# Altium schematic sheet GSM.SchDoc
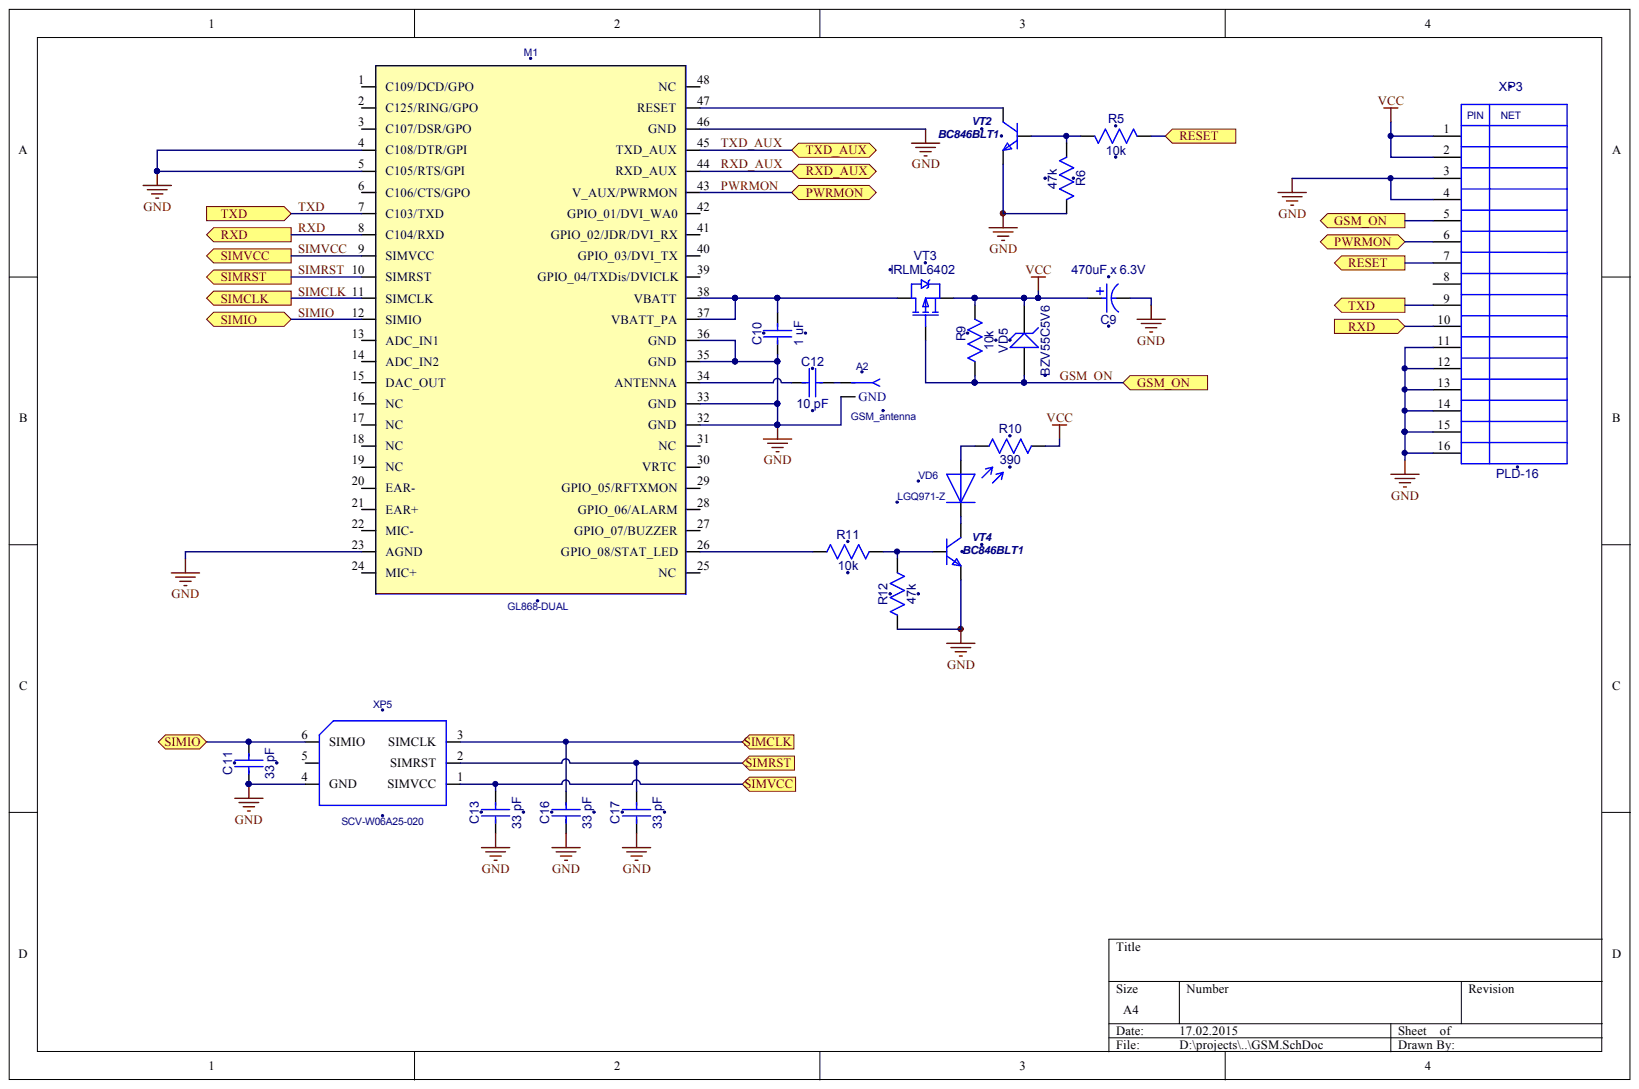
[diagram p0: GSM.SchDoc]

## Parts
A2  GSM_antenna  [GSM_antenna_pad]
C9  470uF x 6.3V  [TAJ-D-1M]
C10  1 uF  [CC0603-3M]
C11  33 pF  [CC0603-3M]
C12  10 pF  [CC0603-3M]
C13  33 pF  [CC0603-3M]
C16  33 pF  [CC0603-3M]
C17  33 pF  [CC0603-3M]
M1  GL868-DUAL  [GL868-DUAL]
R5  10k  [RC0603-3M]
R6  47k  [RC0603-3M]
R9  10k  [RC0603-3M]
R10  390  [RC0603-3M]
R11  10k  [RC0603-3M]
R12  47k  [RC0603-3M]
VD5  BZV55C5V6  [SOD80]
VD6  LGQ971-Z  [LED0603]
VT2  BC846BLT1  [SOT-23(123)]
VT3  IRLML6402  [SOT-23(123)]
VT4  BC846BLT1  [SOT-23(123)]
XP3  PLD-16  [PIN16]
XP5  SCV-W06A25-020  [SCVW06A25020]

## Ports
GSM_ON, PWRMON, RESET, RXD, RXD_AUX, SIMCLK, SIMIO, SIMRST, SIMVCC, TXD, TXD_AUX

## Nets
GND: A2.2 C9.2 C10.1 C11.1 C13.1 C16.1 C17.1 M1.4(C108/DTR/GPI) M1.5(C105/RTS/GPI) M1.23(AGND) M1.32(GND) M1.33(GND) M1.35(GND) M1.36(GND) M1.46(GND) R6.2 R12.2 VT2.2 VT4.2 XP3.3 XP3.4 XP3.11 XP3.12 XP3.13 XP3.14 XP3.15 XP3.16 XP5.4(GND)
VCC: C9.1 R9.1 R10.2 VD5.2 VT3.2 XP3.1 XP3.2
GSM_ON: R9.2 VD5.1 VT3.1 XP3.5 ; port GSM_ON
PWRMON: M1.43(V_AUX/PWRMON) XP3.6 ; port PWRMON
RESET: R5.1 XP3.7 ; port RESET
RXD: M1.8(C104/RXD) XP3.10 ; port RXD
RXD_AUX: M1.44(RXD_AUX) ; port RXD_AUX
SIMCLK: C16.2 M1.11(SIMCLK) XP5.3(SIMCLK) ; port SIMCLK
SIMIO: C11.2 M1.12(SIMIO) XP5.6(SIMIO) ; port SIMIO
SIMRST: C17.2 M1.10(SIMRST) XP5.2(SIMRST) ; port SIMRST
SIMVCC: C13.2 M1.9(SIMVCC) XP5.1(SIMVCC) ; port SIMVCC
TXD: M1.7(C103/TXD) XP3.9 ; port TXD
TXD_AUX: M1.45(TXD_AUX) ; port TXD_AUX
NetA2_1: A2.1 C12.2
NetC10_2: C10.2 M1.37(VBATT_PA) M1.38(VBATT) VT3.3
NetC12_1: C12.1 M1.34(ANTENNA)
NetM1_26: M1.26(GPIO_08/STAT_LED) R11.1
NetM1_47: M1.47(RESET) VT2.3
NetR5_2: R5.2 R6.1 VT2.1
NetR10_1: R10.1 VD6.1
NetR11_2: R11.2 R12.1 VT4.1
NetVD6_2: VD6.2 VT4.3

Not connected: M1 1(C109/DCD/GPO) 2(C125/RING/GPO) 3(C107/DSR/GPO) 6(C106/CTS/GPO) 13(ADC_IN1) 14(ADC_IN2) 15(DAC_OUT) 16(NC) 17(NC) 18(NC) 19(NC) 20(EAR-) 21(EAR+) 22(MIC-) 24(MIC+) 25(NC) 27(GPIO_07/BUZZER) 28(GPIO_06/ALARM) 29(GPIO_05/RFTXMON) 30(VRTC) 31(NC) 39(GPIO_04/TXDis/DVICLK) 40(GPIO_03/DVI_TX) 41(GPIO_02/JDR/DVI_RX) 42(GPIO_01/DVI_WA0) 48(NC); XP3 8; XP5 5

## Library data of the parts
A2 = GSM_antenna_pad: Component Kind: Standard; Description: GSM_antenna_pad; Library Name: gprs_modem.SchLib
C9 = TAJ-D: Component Kind: Standard; Description: TAJ-D; Value: 470uF x 6.3V; Library Name: gprs_modem.SchLib
C10-C13 C16 C17 = CC0603: Component Kind: Standard; Description: CC0603
  C10: Value: 1 uF; Library Name: gprs_modem.SchLib
  C11 C13 C16 C17: Value: 33 pF
  C12: Value: 10 pF
R5 R6 R9-R12 = RC0603: Component Kind: Standard; Description: RC0603
  R5 R9 R11: Value: 10k
  R6 R12: Value: 47k
  R10: Value: 390
  R5 R6 R10-R12: Library Name: gprs_modem.SchLib
VD5 = BZV55C5V1: Zener Voltage Regulator Diode; ComponentField6: Pin 2 is not connected and not drawn.; ComponentField7: Published 29-Sep-2000; OriginalName: BZX84C10LT1; Component Kind: Standard; Simulation: BZX84C10LT1; Value: BZV55C5V6; Library Name: gprs_modem.SchLib
VD6 = LED_0603: Component Kind: Standard; Description: LED_0603; Value: LGQ971-Z; Library Name: gprs_modem.SchLib
VT2 VT4 = BC846BLT1: ComponentField7: Published 29-Sep-2000; Component Kind: Standard; Description: BC846BLT1; OriginalName: BC846BLT1; Simulation: BC846BLT1; Library Name: gprs_modem.SchLib
VT3 = IRLML6402: HEXFET Power MOSFET; ComponentField7: Published 8-Jun-2000; OriginalName: IRLML5103; Value: {Value}; Component Kind: Standard; Library Name: gprs_modem.SchLib
M1 = GL868-DUAL: Component Kind: Standard; Description: GL868-DUAL; Library Name: gprs_modem.SchLib
XP3 = PLD-16: Component Kind: Standard; Description: PLD-16; Library Name: gprs_modem.SchLib
XP5 = SCV-W06A25-020: Component Kind: Standard; Description: SCV-W06A25-020
